# Altium schematic sheet [6]RGB_LCD.SchDoc
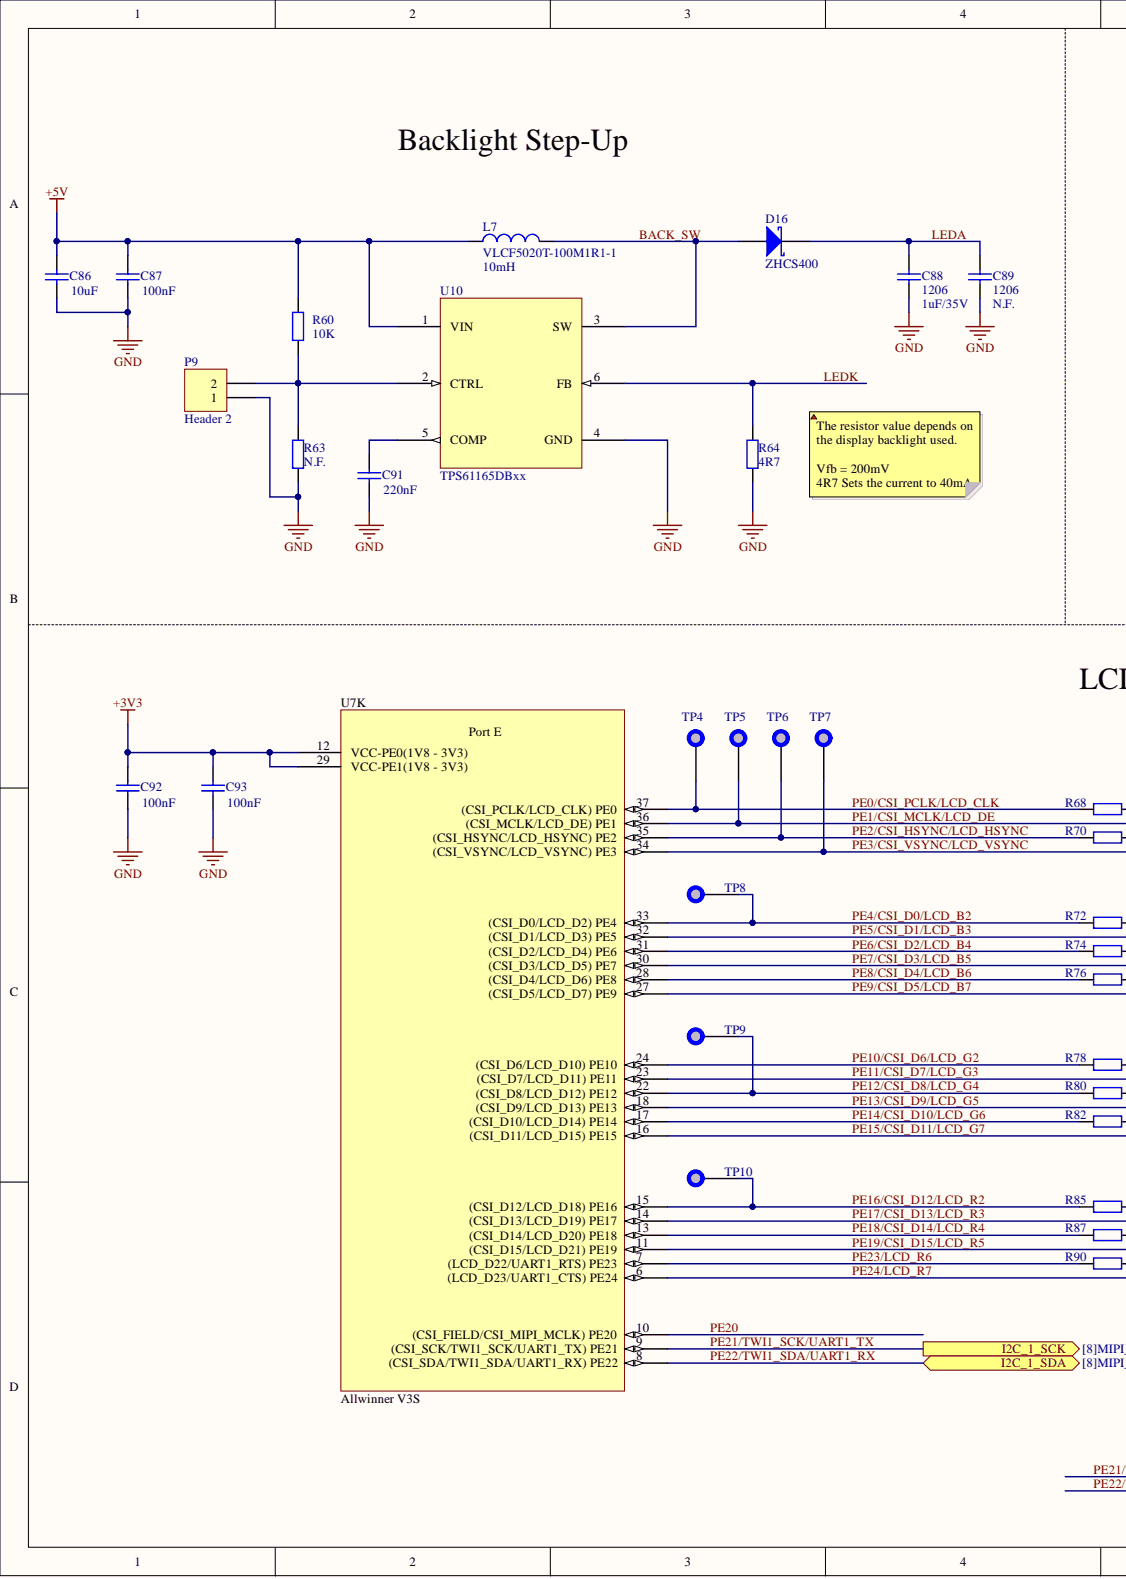
[diagram p0: [6]RGB_LCD.SchDoc, left]
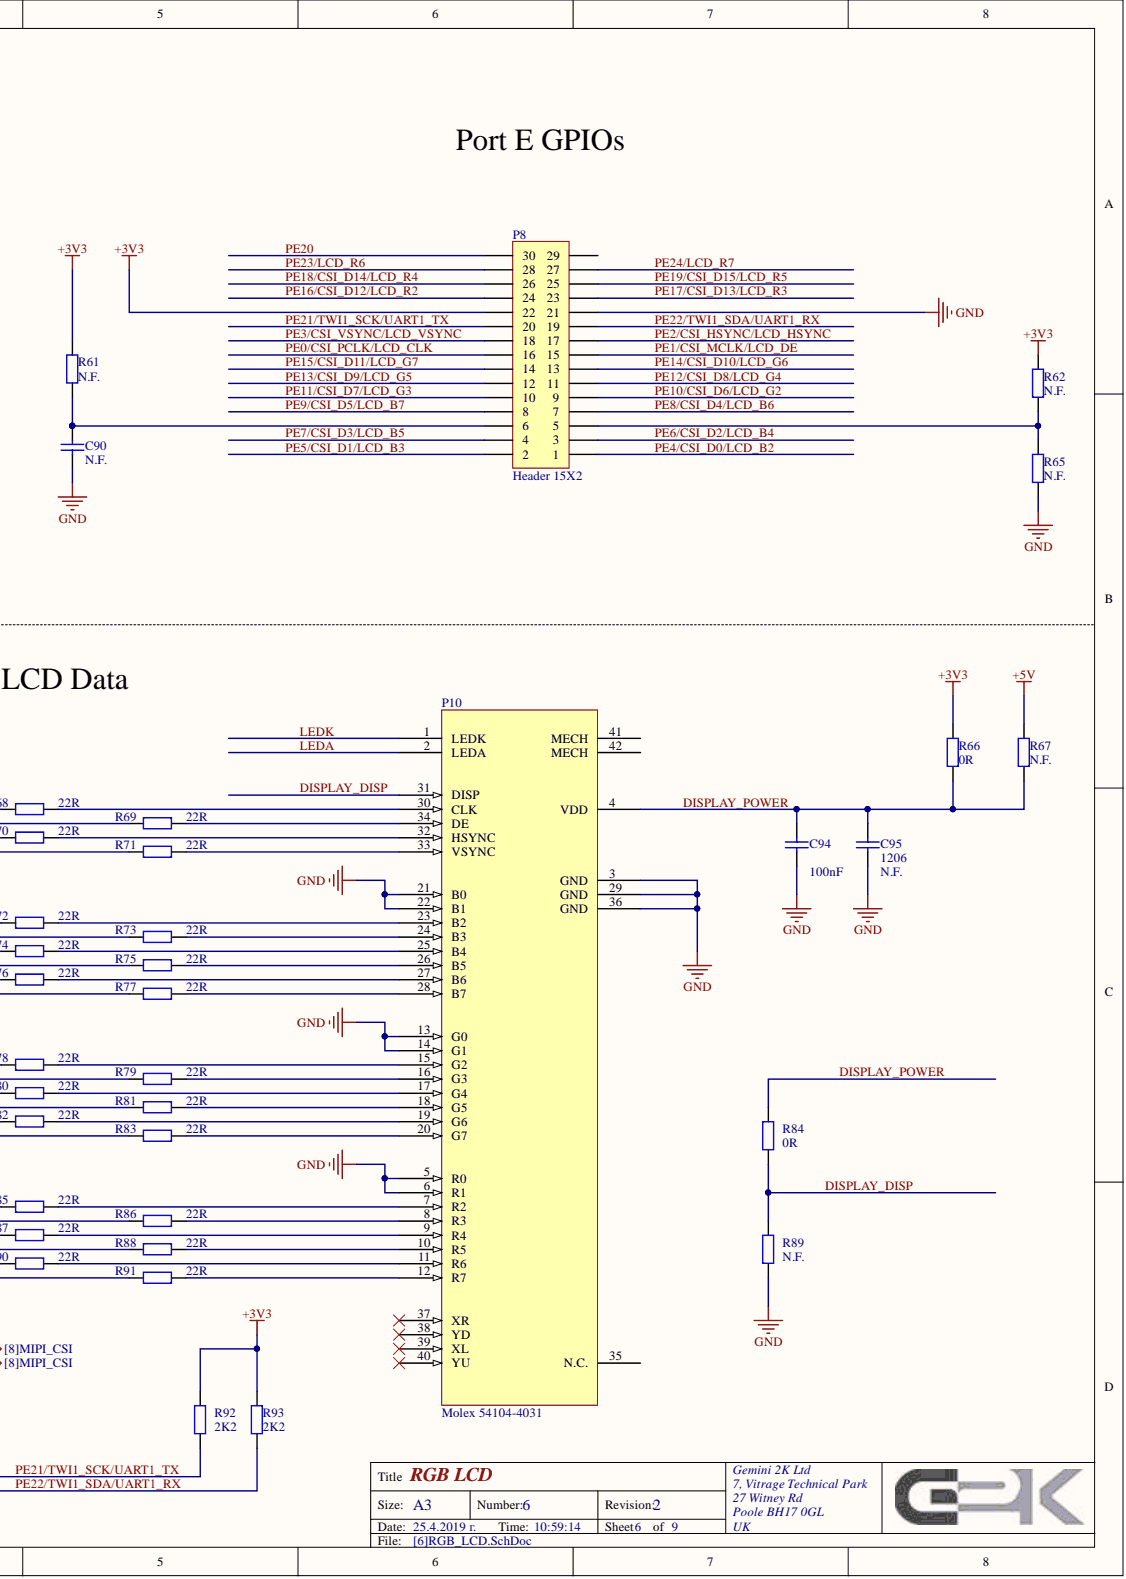
[diagram p1: [6]RGB_LCD.SchDoc, right]

## Parts
C86  value 10uF  [1608[0603]]  (hidden comment: 0603)
C87  value 100nF  [1608[0603]]  (hidden comment: 0603)
C88  1206  value 1uF/35V  [C1206]
C89  1206  value N.F.  [C1206]
C90  value N.F.  [1608[0603]]  (hidden comment: 0603)
C91  value 220nF  [1608[0603]]  (hidden comment: 0603)
C92  value 100nF  [1608[0603]]  (hidden comment: 0603)
C93  value 100nF  [1608[0603]]  (hidden comment: 0603)
C94  value 100nF  [1608[0603]]  (hidden comment: 0603)
C95  1206  value N.F.  [C1206]
D16  ZHCS400  [SOD-323-2]
L7  VLCF5020T-100M1R1-1  value 10mH  [TDK VLCF inductors]
P8  Header 15X2  [HDR2X15]
P9  Header 2  [HDR1X2]
P10  Molex 54104-4031  Manufacturer Name: Molex  [54104-4031]
R60  value 10K  [J1-0603]  (hidden comment: 0603)
R61  value N.F.  [J1-0603]  (hidden comment: 0603)
R62  value N.F.  [J1-0603]  (hidden comment: 0603)
R63  value N.F.  [J1-0603]  (hidden comment: 0603)
R64  value 4R7  [J1-0603]  (hidden comment: 0603)
R65  value N.F.  [J1-0603]  (hidden comment: 0603)
R66  value 0R  [J1-0603]  (hidden comment: 0603)
R67  value N.F.  [J1-0603]  (hidden comment: 0603)
R68  value 22R  [J1-0603]  (hidden comment: 0603)
R69  value 22R  [J1-0603]  (hidden comment: 0603)
R70  value 22R  [J1-0603]  (hidden comment: 0603)
R71  value 22R  [J1-0603]  (hidden comment: 0603)
R72  value 22R  [J1-0603]  (hidden comment: 0603)
R73  value 22R  [J1-0603]  (hidden comment: 0603)
R74  value 22R  [J1-0603]  (hidden comment: 0603)
R75  value 22R  [J1-0603]  (hidden comment: 0603)
R76  value 22R  [J1-0603]  (hidden comment: 0603)
R77  value 22R  [J1-0603]  (hidden comment: 0603)
R78  value 22R  [J1-0603]  (hidden comment: 0603)
R79  value 22R  [J1-0603]  (hidden comment: 0603)
R80  value 22R  [J1-0603]  (hidden comment: 0603)
R81  value 22R  [J1-0603]  (hidden comment: 0603)
R82  value 22R  [J1-0603]  (hidden comment: 0603)
R83  value 22R  [J1-0603]  (hidden comment: 0603)
R84  value 0R  [J1-0603]  (hidden comment: 0603)
R85  value 22R  [J1-0603]  (hidden comment: 0603)
R86  value 22R  [J1-0603]  (hidden comment: 0603)
R87  value 22R  [J1-0603]  (hidden comment: 0603)
R88  value 22R  [J1-0603]  (hidden comment: 0603)
R89  value N.F.  [J1-0603]  (hidden comment: 0603)
R90  value 22R  [J1-0603]  (hidden comment: 0603)
R91  value 22R  [J1-0603]  (hidden comment: 0603)
R92  value 2K2  [J1-0603]  (hidden comment: 0603)
R93  value 2K2  [J1-0603]  (hidden comment: 0603)
TP4  TEST_POINT  [TEST_POINT]  (value not drawn)  (no BOM)
TP5  TEST_POINT  [TEST_POINT]  (value not drawn)  (no BOM)
TP6  TEST_POINT  [TEST_POINT]  (value not drawn)  (no BOM)
TP7  TEST_POINT  [TEST_POINT]  (value not drawn)  (no BOM)
TP8  TEST_POINT  [TEST_POINT]  (value not drawn)  (no BOM)
TP9  TEST_POINT  [TEST_POINT]  (value not drawn)  (no BOM)
TP10  TEST_POINT  [TEST_POINT]  (value not drawn)  (no BOM)
U7  Allwinner V3S  Manufacturer Name: Allwinner  Manufacturer Part No.: V3S  [LQFP128 E-PAD - Low Density]  (part K)
U10  TPS61165DBxx  [SOT100P275-6N]

## Ports
I2C_1_SCK, I2C_1_SDA

## Nets
+3V3: C92.2 C93.2 P8.22 R61.2 R62.2 R66.1 R92.2 R93.2 U7.12(VCC-PE0(1V8_-_3V3)) U7.29(VCC-PE1(1V8_-_3V3))
+5V: C86.2 C87.2 L7.1 R60.2 R67.1 U10.1(VIN)
GND: C86.1 C87.1 C88.1 C89.1 C90.1 C91.1 C92.1 C93.1 C94.1 C95.1 P8.21 P9.1 P10.3(GND) P10.5(R0) P10.6(R1) P10.13(G0) P10.14(G1) P10.21(B0) P10.22(B1) P10.29(GND) P10.36(GND) R63.1 R64.2 R65.1 R89.1 U10.4(GND)
BACK_SW: D16.1 L7.2 U10.3(SW)
DISPLAY_DISP: P10.31(DISP) R84.1 R89.2
DISPLAY_POWER: C94.2 C95.2 P10.4(VDD) R66.2 R67.2 R84.2
LEDA: C88.2 C89.2 D16.2 P10.2(LEDA)
LEDK: P10.1(LEDK) R64.1 U10.6(FB)
PE0/CSI_PCLK/LCD_CLK: P8.16 R68.2 TP4.1 U7.37((CSI_PCLK/LCD_CLK)_PE0)
PE1/CSI_MCLK/LCD_DE: P8.15 R69.2 TP5.1 U7.36((CSI_MCLK/LCD_DE)_PE1)
PE2/CSI_HSYNC/LCD_HSYNC: P8.17 R70.2 TP6.1 U7.35((CSI_HSYNC/LCD_HSYNC)_PE2)
PE3/CSI_VSYNC/LCD_VSYNC: P8.18 R71.2 TP7.1 U7.34((CSI_VSYNC/LCD_VSYNC)_PE3)
PE4/CSI_D0/LCD_B2: P8.1 R72.2 TP8.1 U7.33((CSI_D0/LCD_D2)_PE4)
PE5/CSI_D1/LCD_B3: P8.2 R73.2 U7.32((CSI_D1/LCD_D3)_PE5)
PE6/CSI_D2/LCD_B4: P8.3 R74.2 U7.31((CSI_D2/LCD_D4)_PE6)
PE7/CSI_D3/LCD_B5: P8.4 R75.2 U7.30((CSI_D3/LCD_D5)_PE7)
PE8/CSI_D4/LCD_B6: P8.7 R76.2 U7.28((CSI_D4/LCD_D6)_PE8)
PE9/CSI_D5/LCD_B7: P8.8 R77.2 U7.27((CSI_D5/LCD_D7)_PE9)
PE10/CSI_D6/LCD_G2: P8.9 R78.2 U7.24((CSI_D6/LCD_D10)_PE10)
PE11/CSI_D7/LCD_G3: P8.10 R79.2 U7.23((CSI_D7/LCD_D11)_PE11)
PE12/CSI_D8/LCD_G4: P8.11 R80.2 TP9.1 U7.22((CSI_D8/LCD_D12)_PE12)
PE13/CSI_D9/LCD_G5: P8.12 R81.2 U7.18((CSI_D9/LCD_D13)_PE13)
PE14/CSI_D10/LCD_G6: P8.13 R82.2 U7.17((CSI_D10/LCD_D14)_PE14)
PE15/CSI_D11/LCD_G7: P8.14 R83.2 U7.16((CSI_D11/LCD_D15)_PE15)
PE16/CSI_D12/LCD_R2: P8.24 R85.2 TP10.1 U7.15((CSI_D12/LCD_D18)_PE16)
PE17/CSI_D13/LCD_R3: P8.23 R86.2 U7.14((CSI_D13/LCD_D19)_PE17)
PE18/CSI_D14/LCD_R4: P8.26 R87.2 U7.13((CSI_D14/LCD_D20)_PE18)
PE19/CSI_D15/LCD_R5: P8.25 R88.2 U7.11((CSI_D15/LCD_D21)_PE19)
PE20: P8.30 U7.10((CSI_FIELD/CSI_MIPI_MCLK)_PE20)
PE21/TWI1_SCK/UART1_TX: P8.20 R92.1 U7.9((CSI_SCK/TWI1_SCK/UART1_TX)_PE21) ; port I2C_1_SCK
PE22/TWI1_SDA/UART1_RX: P8.19 R93.1 U7.8((CSI_SDA/TWI1_SDA/UART1_RX)_PE22) ; port I2C_1_SDA
PE23/LCD_R6: P8.28 R90.2 U7.7((LCD_D22/UART1_RTS)_PE23)
PE24/LCD_R7: P8.27 R91.2 U7.6((LCD_D23/UART1_CTS)_PE24)
NetC90_2: C90.2 P8.6 R61.1
NetC91_2: C91.2 U10.5(COMP)
NetP8_5: P8.5 R62.1 R65.2
NetP9_2: P9.2 R60.1 R63.2 U10.2(CTRL)
NetP10_7: P10.7(R2) R85.1
NetP10_8: P10.8(R3) R86.1
NetP10_9: P10.9(R4) R87.1
NetP10_10: P10.10(R5) R88.1
NetP10_11: P10.11(R6) R90.1
NetP10_12: P10.12(R7) R91.1
NetP10_15: P10.15(G2) R78.1
NetP10_16: P10.16(G3) R79.1
NetP10_17: P10.17(G4) R80.1
NetP10_18: P10.18(G5) R81.1
NetP10_19: P10.19(G6) R82.1
NetP10_20: P10.20(G7) R83.1
NetP10_23: P10.23(B2) R72.1
NetP10_24: P10.24(B3) R73.1
NetP10_25: P10.25(B4) R74.1
NetP10_26: P10.26(B5) R75.1
NetP10_27: P10.27(B6) R76.1
NetP10_28: P10.28(B7) R77.1
NetP10_30: P10.30(CLK) R68.1
NetP10_32: P10.32(HSYNC) R70.1
NetP10_33: P10.33(VSYNC) R71.1
NetP10_34: P10.34(DE) R69.1

Not connected: P8 29; P10 35(N.C.) 37(XR) 38(YD) 39(XL) 40(YU) 41(MECH) 42(MECH)

## Library data of the parts
C86 C88-C91 C95 = Cap: Capacitor; Component Kind: Standard; LatestRevisionDate: 17-Jul-2002; LatestRevisionNote: Re-released for DXP Platform.; Library Name: Miscellaneous Devices.IntLib; PackageDescription: Radial Cap, Thru-Hole; 2 Leads; 0.3 in Pin Spacing; PackageReference: RAD-0.3; Published: 8-Jun-2000; Signal Integrity: Cap; Simulation: CAP; Publisher: Altium Limited
  C86: Case Code (in): 0603; Distributor Link: https://eu.mouser.com/ProductDetail/Taiyo-Yuden/JMK107ABJ106MA-T?qs=sGAEpiMZZMvsSlwiRhF8qtYrDJZp5VWM0KJHW32Kpw4hdLhJsFnoCA%3d%3d
  C88: Case Code (in): 1206; Distributor Link: https://eu.mouser.com/ProductDetail/Taiyo-Yuden/GMK316BJ105MLHT?qs=sGAEpiMZZMs0AnBnWHyRQEdbEG7jlyBqxYCpqkmofU%252bjQFUCII9P8g%3d%3d
  C89 C95: Case Code (in): 1206
  C90: Case Code (in): 0603
  C91: Case Code (in): 0603; Distributor Link: https://eu.mouser.com/ProductDetail/Taiyo-Yuden/LMK107B7224KA-T?qs=sGAEpiMZZMvsSlwiRhF8qgJCQaFFkNE%2feb1h7jpygT0%3d
C87 C92-C94 = Cap: Capacitor; Note: We have them in Bulgaria; Case Code (in): 0603; Component Kind: Standard; Distributor Link: http://uk.farnell.com/kemet/c0603x104k4ractu/cap-mlcc-x7r-100nf-16v-0603/dp/1414027; LatestRevisionDate: 17-Jul-2002; LatestRevisionNote: Re-released for DXP Platform.; Library Name: Miscellaneous Devices.IntLib; PackageDescription: Radial Cap, Thru-Hole; 2 Leads; 0.3 in Pin Spacing; PackageReference: RAD-0.3; Published: 8-Jun-2000; Signal Integrity: Cap; Simulation: CAP; Publisher: Altium Limited
D16 = D Schottky: Schottky Diode; Manufacturer Name: Diodes Incorporated; Code_JEDEC: DO-214-AA; Component Kind: Standard; Distributor Link: https://uk.farnell.com/diodes-inc/zhcs400/diode-schottky-sod-323/dp/9525149; LatestRevisionDate: 17-Jul-2002; LatestRevisionNote: Re-released for DXP Platform.; Library Name: Miscellaneous Devices.IntLib; Manufacturer Part No.: ZHCS400; PackageDescription: DO-214-AA/SMB; 2 C-Bend Leads; Body 5.3 x 3.6 mm, inc. leads (LxW); PackageReference: SMB; Published: 8-Jun-2000; Signal Integrity: D Schottky; Simulation: SKYDIODE; Publisher: Altium Limited
L7 = Inductor: Manufacturer Name: TDK; Code_IEC: 1005; Code_JEITA: 0402; Component Kind: Standard; Description: Inductor; Distributor Link: https://uk.farnell.com/tdk/vlcf5020t-100m1r1-1/inductor-10uh-a-power-line/dp/1670091; LatestRevisionDate: 29-May-2009; LatestRevisionNote: IPC-7351 Footprint Added.; Library Name: Miscellaneous Devices.IntLib; Manufacturer Part No.: VLCF5020T-100M1R1-1; PackageDescription: Chip Inductor; PackageReference: 0402-A; Published: 8-Jun-2000; Signal Integrity: Inductor; Simulation: INDUCTOR; Publisher: Altium Limited
P8 = Header 15X2: Header, 15-Pin, Dual row; Component Kind: Standard; LatestRevisionDate: 17-Jul-2002; LatestRevisionNote: Re-released for DXP Platform.; Library Name: Miscellaneous Connectors.IntLib; Signal Integrity: Connector; Publisher: Altium Limited
P9 = Header 2: Header, 2-Pin; Value: N.F.; Component Kind: Standard; LatestRevisionDate: 17-Jul-2002; LatestRevisionNote: Re-released for DXP Platform.; Library Name: Miscellaneous Connectors.IntLib; Signal Integrity: Connector; Publisher: Altium Limited
P10 = Molex 505110-4091: Component Kind: Standard; Distributor Link: https://uk.farnell.com/molex/54104-4031/connector-fpc-0-5mm-40way/dp/1757126; Manufacturer Part No.: 54104-4031; Library Name: G2Pay_Lib.SchLib
R60-R65 R67 R89 R92 R93 = Res2: Resistor; Case Code (in): 0603; Component Kind: Standard; LatestRevisionDate: 17-Jul-2002; LatestRevisionNote: Re-released for DXP Platform.; Library Name: Miscellaneous Devices.IntLib; PackageDescription: Axial Device, Thru-Hole; 2 Leads; 0.4 in Pin Spacing; PackageReference: AXIAL-0.4; Published: 8-Jun-2000; Signal Integrity: Res; Simulation: RESISTOR; Publisher: Altium Limited
  R60: Distributor Link: http://uk.farnell.com/multicomp/mcwr06x1002ftl/res-thick-film-10k-1-0-1w-0603/dp/2447230
  R64: Distributor Link: https://uk.farnell.com/multicomp/mcwr06w4r70ftl/res-4r7-1-0-1w-thick-film/dp/2447390
  R92 R93: Distributor Link: https://uk.farnell.com/yageo/rc0603fr-072k2l/res-2k2-1-0-1w-0603-thick-film/dp/9238522
R66 R68-R88 R90 R91 = Res2: Resistor; Note: We have them in Bulgaria; Case Code (in): 0603; Component Kind: Standard; LatestRevisionDate: 17-Jul-2002; LatestRevisionNote: Re-released for DXP Platform.; Library Name: Miscellaneous Devices.IntLib; PackageDescription: Axial Device, Thru-Hole; 2 Leads; 0.4 in Pin Spacing; PackageReference: AXIAL-0.4; Published: 8-Jun-2000; Signal Integrity: Res; Simulation: RESISTOR; Publisher: Altium Limited
  R66 R84: Distributor Link: http://uk.farnell.com/yageo/rc0603fr-070rl/res-thick-film-0r-1-0-1w-0603/dp/2309106
  R68-R83 R85-R88 R90 R91: Distributor Link: https://uk.farnell.com/multicomp/mcwr06x22r0ftl/res-22r-1-0-1w-thick-film/dp/2447301
U10 = TPS61165DBVx: High-Brightness, White LED Driver; Manufacturer Name: TI; Component Kind: Standard; Distributor Link: https://eu.mouser.com/ProductDetail/Texas-Instruments/TPS61165DBVR?qs=sGAEpiMZZMtnFuLo2AlFPpgVxpAWFryIN7wlfxWKnvU%3d; Manufacturer Part No.: TPS61165DBxx; Library Name: G2Pay_Lib.SchLib
U7 = Allwinner V3S: Component Kind: Standard; Library Name: Allwinner.SchLib
TP4-TP10 = TEST_POINT: Library Name: OrbitCrypto.SchLib
